# Altium schematic sheet EXTERNAL.SchDoc
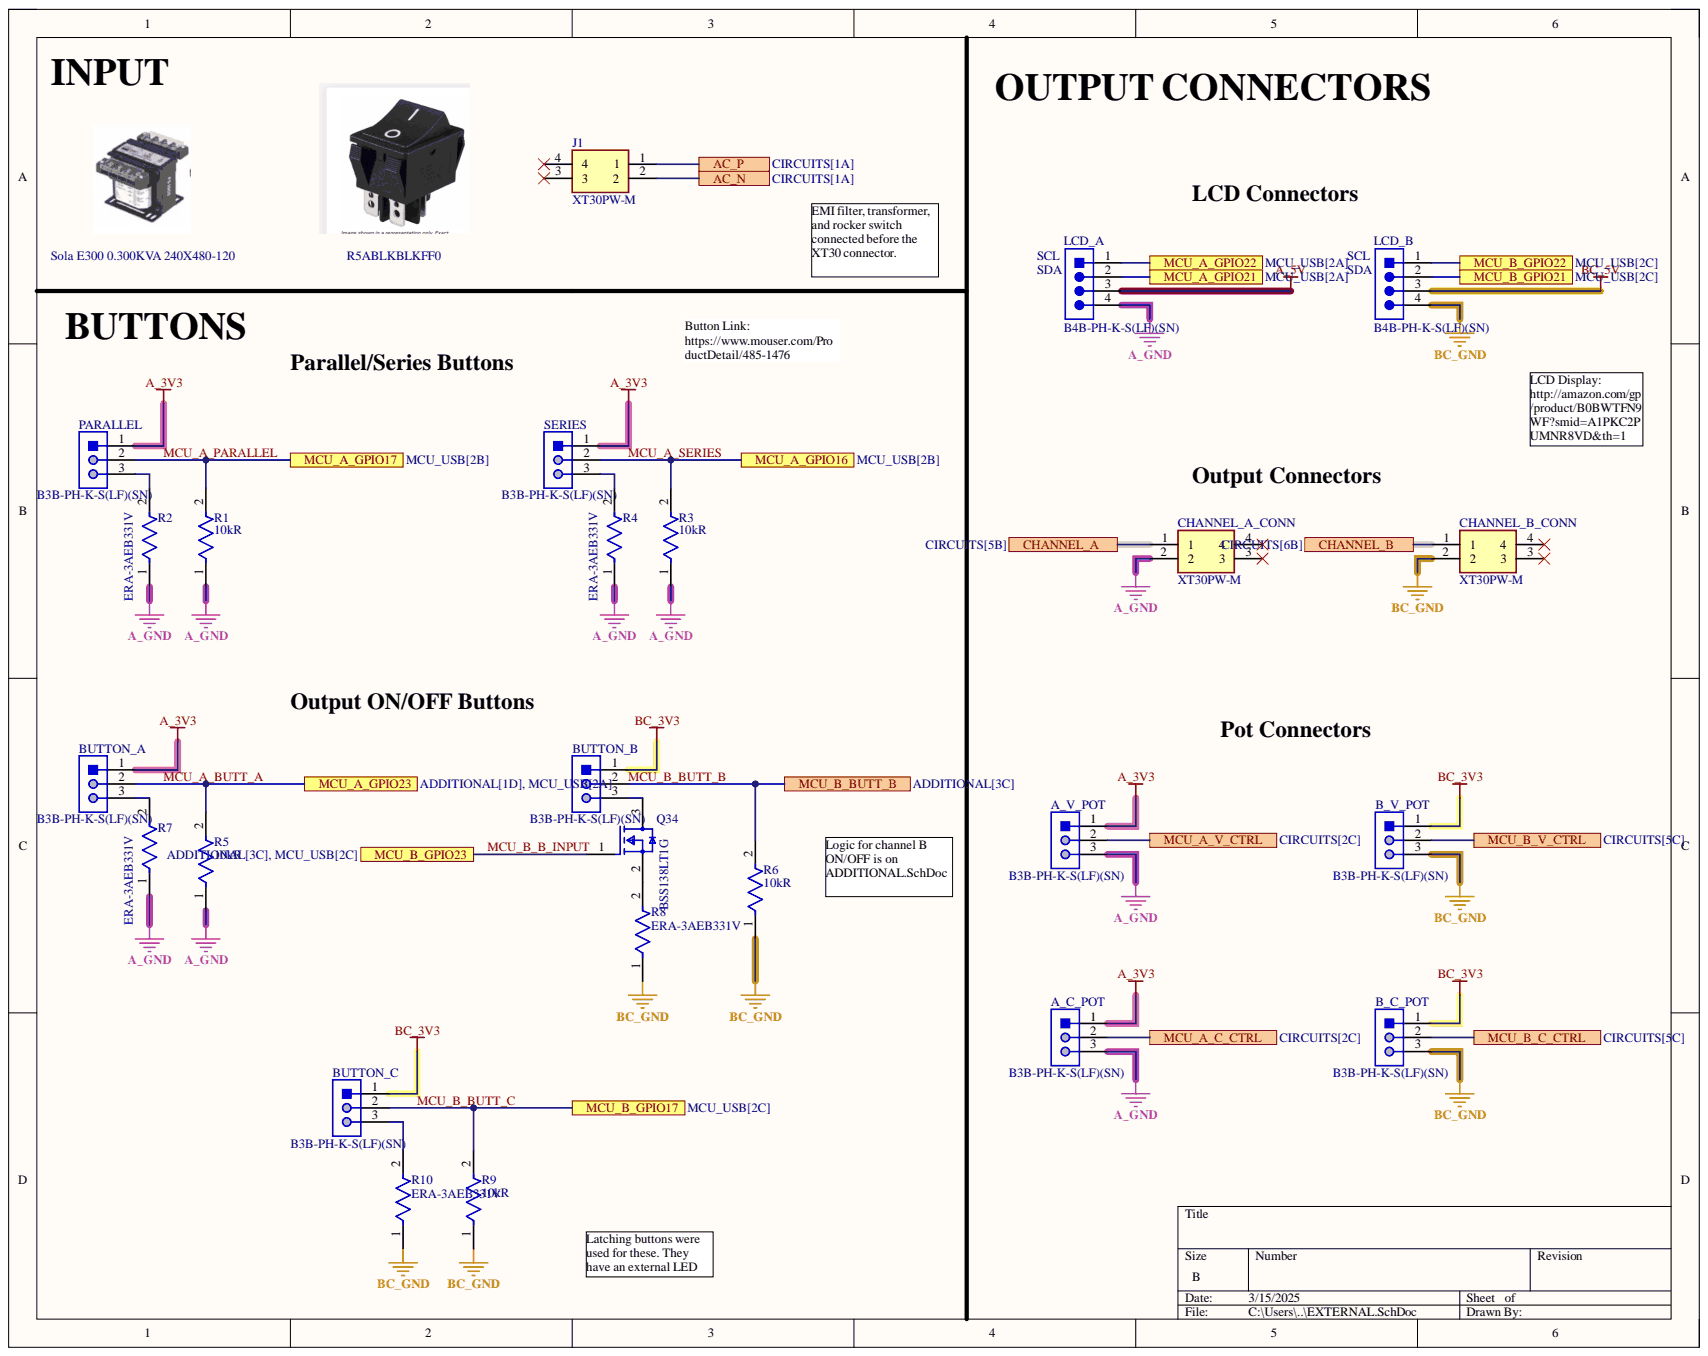
[diagram p0: EXTERNAL.SchDoc]

## Parts
A_C_POT  B3B-PH-K-S(LF)(SN)  [JST-B3B-PH-K-S-3_V]
A_V_POT  B3B-PH-K-S(LF)(SN)  [JST-B3B-PH-K-S-3_V]
B_C_POT  B3B-PH-K-S(LF)(SN)  [JST-B3B-PH-K-S-3_V]
B_V_POT  B3B-PH-K-S(LF)(SN)  [JST-B3B-PH-K-S-3_V]
BUTTON_A  B3B-PH-K-S(LF)(SN)  [JST-B3B-PH-K-S-3_V]
BUTTON_B  B3B-PH-K-S(LF)(SN)  [JST-B3B-PH-K-S-3_V]
BUTTON_C  B3B-PH-K-S(LF)(SN)  [JST-B3B-PH-K-S-3_V]
CHANNEL_A_CONN  XT30PW-M  [XT30PWM]
CHANNEL_B_CONN  XT30PW-M  [XT30PWM]
J1  XT30PW-M  [XT30PWM]
LCD_A  B4B-PH-K-S(LF)(SN)  [JST-B4B-PH-K-S_V]
LCD_B  B4B-PH-K-S(LF)(SN)  [JST-B4B-PH-K-S_V]
PARALLEL  B3B-PH-K-S(LF)(SN)  [JST-B3B-PH-K-S-3_V]
Q34  BSS138LT1G  [FP-318-MFG]
R1  Resistance: 10kR  [FP-RC0603-0_55-IPC_C]  (hidden comment: RC0603FR-0710KL)
R2  ERA-3AEB331V  [FP-ERA3A-MFG]
R3  Resistance: 10kR  [FP-RC0603-0_55-IPC_C]  (hidden comment: RC0603FR-0710KL)
R4  ERA-3AEB331V  [FP-ERA3A-MFG]
R5  Resistance: 10kR  [FP-RC0603-0_55-IPC_C]  (hidden comment: RC0603FR-0710KL)
R6  Resistance: 10kR  [FP-RC0603-0_55-IPC_C]  (hidden comment: RC0603FR-0710KL)
R7  ERA-3AEB331V  [FP-ERA3A-MFG]
R8  ERA-3AEB331V  [FP-ERA3A-MFG]
R9  Resistance: 10kR  [FP-RC0603-0_55-IPC_C]  (hidden comment: RC0603FR-0710KL)
R10  ERA-3AEB331V  [FP-ERA3A-MFG]
SERIES  B3B-PH-K-S(LF)(SN)  [JST-B3B-PH-K-S-3_V]

## Ports
AC_N, AC_P, CHANNEL_A, CHANNEL_B, MCU_A_C_CTRL, MCU_A_GPIO16, MCU_A_GPIO17, MCU_A_GPIO21, MCU_A_GPIO22, MCU_A_GPIO23, MCU_A_V_CTRL, MCU_B_BUTT_B, MCU_B_C_CTRL, MCU_B_GPIO17, MCU_B_GPIO21, MCU_B_GPIO22, MCU_B_GPIO23, MCU_B_V_CTRL

## Nets
A_3V3: A_C_POT.1 A_V_POT.1 BUTTON_A.1 PARALLEL.1 SERIES.1
A_5V: LCD_A.3
A_GND: A_C_POT.3 A_V_POT.3 CHANNEL_A_CONN.2 LCD_A.4 R1.1 R2.1 R3.1 R4.1 R5.1 R7.1
BC_3V3: B_C_POT.1 B_V_POT.1 BUTTON_B.1 BUTTON_C.1
BC_5V: LCD_B.3
BC_GND: B_C_POT.3 B_V_POT.3 CHANNEL_B_CONN.2 LCD_B.4 R6.1 R8.1 R9.1 R10.1
AC_N: J1.2 ; port AC_N
AC_P: J1.1 ; port AC_P
CHANNEL_A: CHANNEL_A_CONN.1 ; port CHANNEL_A
CHANNEL_B: CHANNEL_B_CONN.1 ; port CHANNEL_B
MCU_A_BUTT_A: BUTTON_A.2 R5.2 ; port MCU_A_GPIO23
MCU_A_C_CTRL: A_C_POT.2 ; port MCU_A_C_CTRL
MCU_A_GPIO21: LCD_A.2 ; port MCU_A_GPIO21
MCU_A_GPIO22: LCD_A.1 ; port MCU_A_GPIO22
MCU_A_PARALLEL: PARALLEL.2 R1.2 ; port MCU_A_GPIO17
MCU_A_SERIES: R3.2 SERIES.2 ; port MCU_A_GPIO16
MCU_A_V_CTRL: A_V_POT.2 ; port MCU_A_V_CTRL
MCU_B_B_INPUT: Q34.1 ; port MCU_B_GPIO23
MCU_B_BUTT_B: BUTTON_B.2 R6.2 ; port MCU_B_BUTT_B
MCU_B_BUTT_C: BUTTON_C.2 R9.2 ; port MCU_B_GPIO17
MCU_B_C_CTRL: B_C_POT.2 ; port MCU_B_C_CTRL
MCU_B_GPIO21: LCD_B.2 ; port MCU_B_GPIO21
MCU_B_GPIO22: LCD_B.1 ; port MCU_B_GPIO22
MCU_B_V_CTRL: B_V_POT.2 ; port MCU_B_V_CTRL
NetBUTTON_A_3: BUTTON_A.3 R7.2
NetBUTTON_B_3: BUTTON_B.3 Q34.3
NetBUTTON_C_3: BUTTON_C.3 R10.2
NetPARALLEL_3: PARALLEL.3 R2.2
NetQ34_2: Q34.2 R8.2
NetR4_2: R4.2 SERIES.3

Not connected: CHANNEL_A_CONN 3 4; CHANNEL_B_CONN 3 4; J1 3 4

## Library data of the parts
A_C_POT A_V_POT B_C_POT B_V_POT BUTTON_A BUTTON_B BUTTON_C PARALLEL SERIES = CMP-2000-05164-1: Male Header, Pitch 2 mm, 1 x 3 Position, Height 6 mm, Tail Length 3.4 mm, -25 to 85 degC, RoHS, Bulk; Manufacturer: JST; No of Positions: 3; Pitch: 2mm; Style/Orientation: StraightHeader/Plug; Component Kind: Standard; Datasheet URL: https://datasheet.ciiva.com/11746/0900766b80826550-11746939.pdf; Datasheet Version: Oct-06; Library Name: Altium Content Vault; Manufacturer URL: http://www.jst.com/home2.html; Termination: Crimp; Type: ShroudedHeader
CHANNEL_A_CONN CHANNEL_B_CONN J1 = CMP-003-000002-1: 30A Low Temperature Rise Fire Retardant Plug; Manufacturer_Name: Amass; Geometry.Height: 5mm; Component Kind: Standard; Datasheet Link: https://www.tme.eu/sl/Document/ce4077e36b79046da520ca73227e15de/XT30PW%20SPEC.pdf; Design Item ID: XT30PW-M; Manufacturer_Part_Number: XT30PW-M; Source: XT30PW-M.SchLib; Library Name: Cooper IGVC
LCD_A LCD_B = CMP-2000-05165-1: Male Header, Pitch 2 mm, 1 x 4 Position, Height 6 mm, Tail Length 3.4 mm, -25 to 85 degC, RoHS, Bulk; Manufacturer: JST; No of Positions: 4; Pitch: 2mm; Style/Orientation: StraightHeader/Plug; Component Kind: Standard; Datasheet URL: http://datasheet.octopart.com/B4B-PH-K-S%28LF%29%28SN%29-JST-datasheet-10558886.pdf; Datasheet Version: Apr-09; Library Name: Altium Content Vault; Manufacturer URL: http://www.jst.com/home2.html; Termination: Crimp; Type: ShroudedHeader
Q34 = CMP-1058-00767-2: Manufacturer Lifecycle Status: ACTIVE; NexarPartId: 5035; Continuous Drain Current (ID): 200mA; Drain to Source Breakdown Voltage: 50V; Drain to Source Resistance: 3.5Ω; Element Configuration: Single; Gate to Source Voltage (Vgs): 20V; Input Capacitance: 50pF; Max Junction Temperature (Tj): 150°C; Max Power Dissipation: 225mW; Nominal Vgs: 1.5V; Number of Channels: 1; Turn-Off Delay Time: 20ns; Case/Package: SOT-23-3; Component Kind: Standard; Contact Plating: Tin; Current Rating: 200mA; Drain to Source Voltage (Vdss): 50V; Halogen Free: Halogen Free; Height: 1.01mm; Lead Free: Lead Free; Length: 3.04mm; Library Name: Altium Content Vault; Lifecycle Status: Production; Max Operating Temperature: 150°C; Min Operating Temperature: -55°C; Number of Elements: 1; Number of Pins: 3; Packaging: Cut Tape; Power Dissipation: 225mW; Radiation Hardening: No; Rds On Max: 3.5Ω; REACH SVHC: No SVHC; Resistance: 3.5Ω; RoHS: Compliant; Schedule B: 8541210080; Threshold Voltage: 1.5V; Turn-On Delay Time: 20ns; Voltage Rating (DC): 50V; Width: 1.4mm
R1 R3 R5 R6 R9 = CMP-2100-03664-2: RES 10K OHM 1% 1/10W 0603; Max Power Dissipation: 100mW; Temperature Coefficient: 100ppm/°C; Voltage Rating: 75V; Voltage Rating (DC): 50V; Case Code (Imperial): 0603; Case Code (Metric): 1608; Case/Package: 0603; Component Kind: Standard; Construction: Rectangular; Depth: 0.8mm; Features: Moisture Resistant; Height: 0.45mm; Lead Free: Lead Free; Lead Pitch: 0.3mm; Length: 1.6mm; Library Name: Altium Content Vault; Max Operating Temperature: 155°C; Military Standard: Not; Min Operating Temperature: -55°C; Number of Pins: 2; Number of Terminations: 2; Packaging: Tape and Reel; Power Rating: 100mW; Resistance Tolerance: 1%; Resistor Type: Thick Film; RoHS Compliant: Yes; Series: RC; Size Code: 0603; Termination: SMD/SMT; Tolerance: 1%; Weight: 7.1E-05oz; Width: 0.8mm
R2 R4 R7 R8 R10 = CMP-2000-00036-3: NexarPartId: 1187882; Max Power Dissipation: 100mW; Resistance: 330Ω; Temperature Coefficient: 25ppm/°C; Tolerance: 0.1%; Voltage Rating: 75V; Case Code (Imperial): 0603; Case Code (Metric): 1608; Case/Package: 0603; Component Kind: Standard; Composition: Thin Film; Contact Plating: Tin; Depth: 800µm; Features: Automotive AEC-Q200; Height: 450µm; Lead Free: Lead Free; Length: 1.6mm; Library Name: Altium Content Vault; Lifecycle Status: Production; Max Operating Temperature: 155°C; Military Standard: Not; Min Operating Temperature: -55°C; Mount: Surface Mount; Number of Pins: 2; Number of Terminations: 2; Packaging: Cut Tape; Power Rating: 100mW; Radiation Hardening: No; REACH SVHC: No SVHC; RoHS: Compliant; Schedule B: 8533210045, 8533210045; Termination: SMD/SMT; Voltage Rating (DC): 75V; Width: 800µm
